# Altium schematic sheet 2.POWER.SchDoc
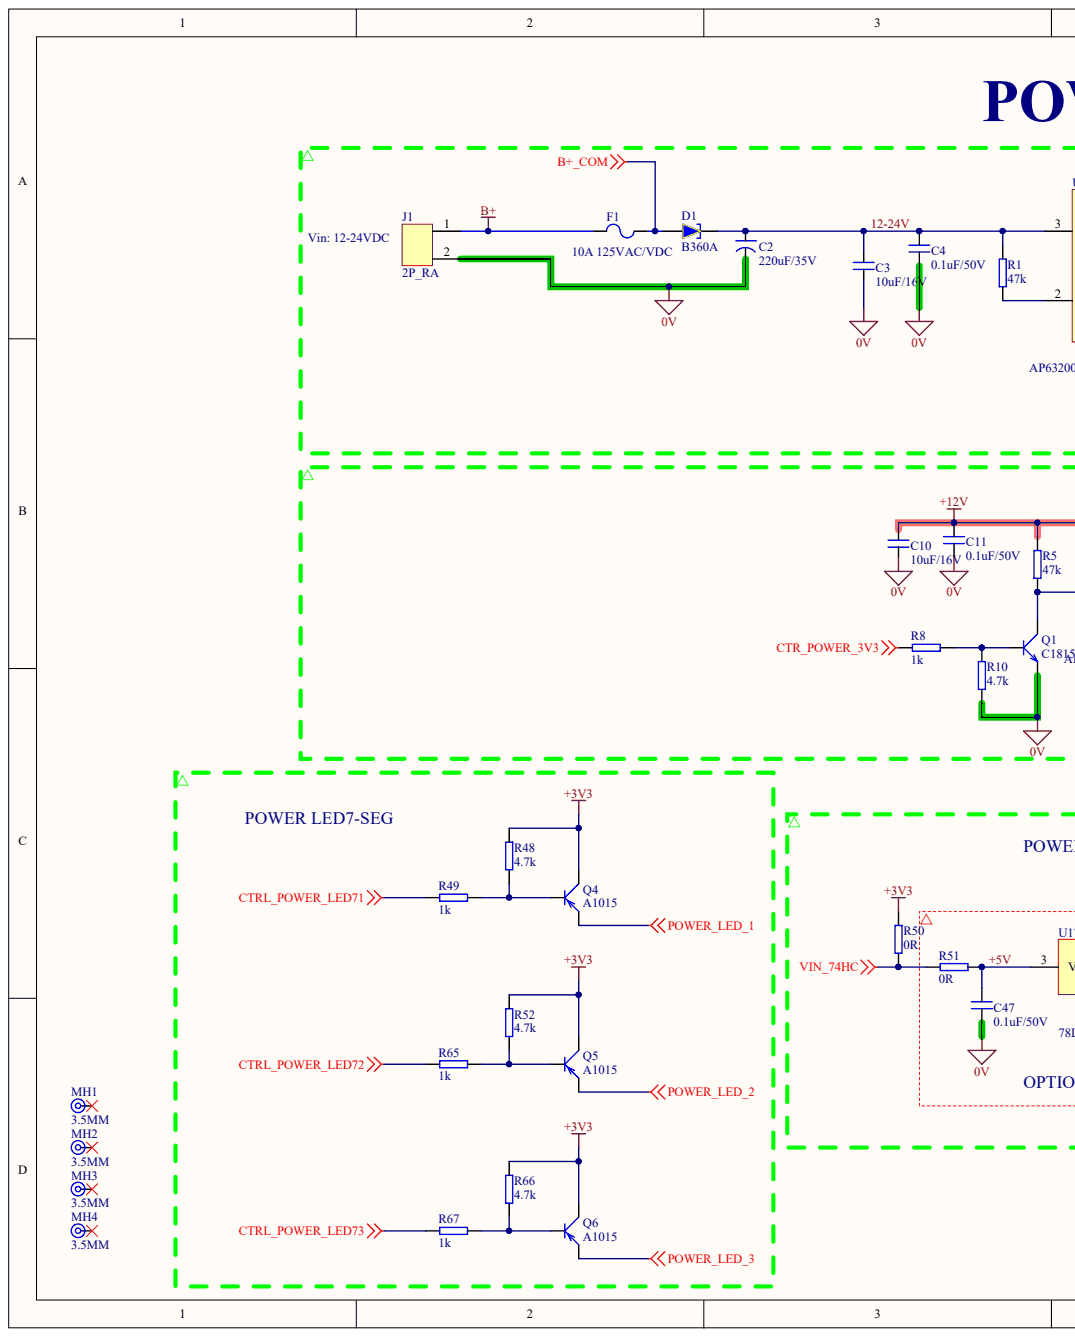
[diagram p0: 2.POWER.SchDoc, left]
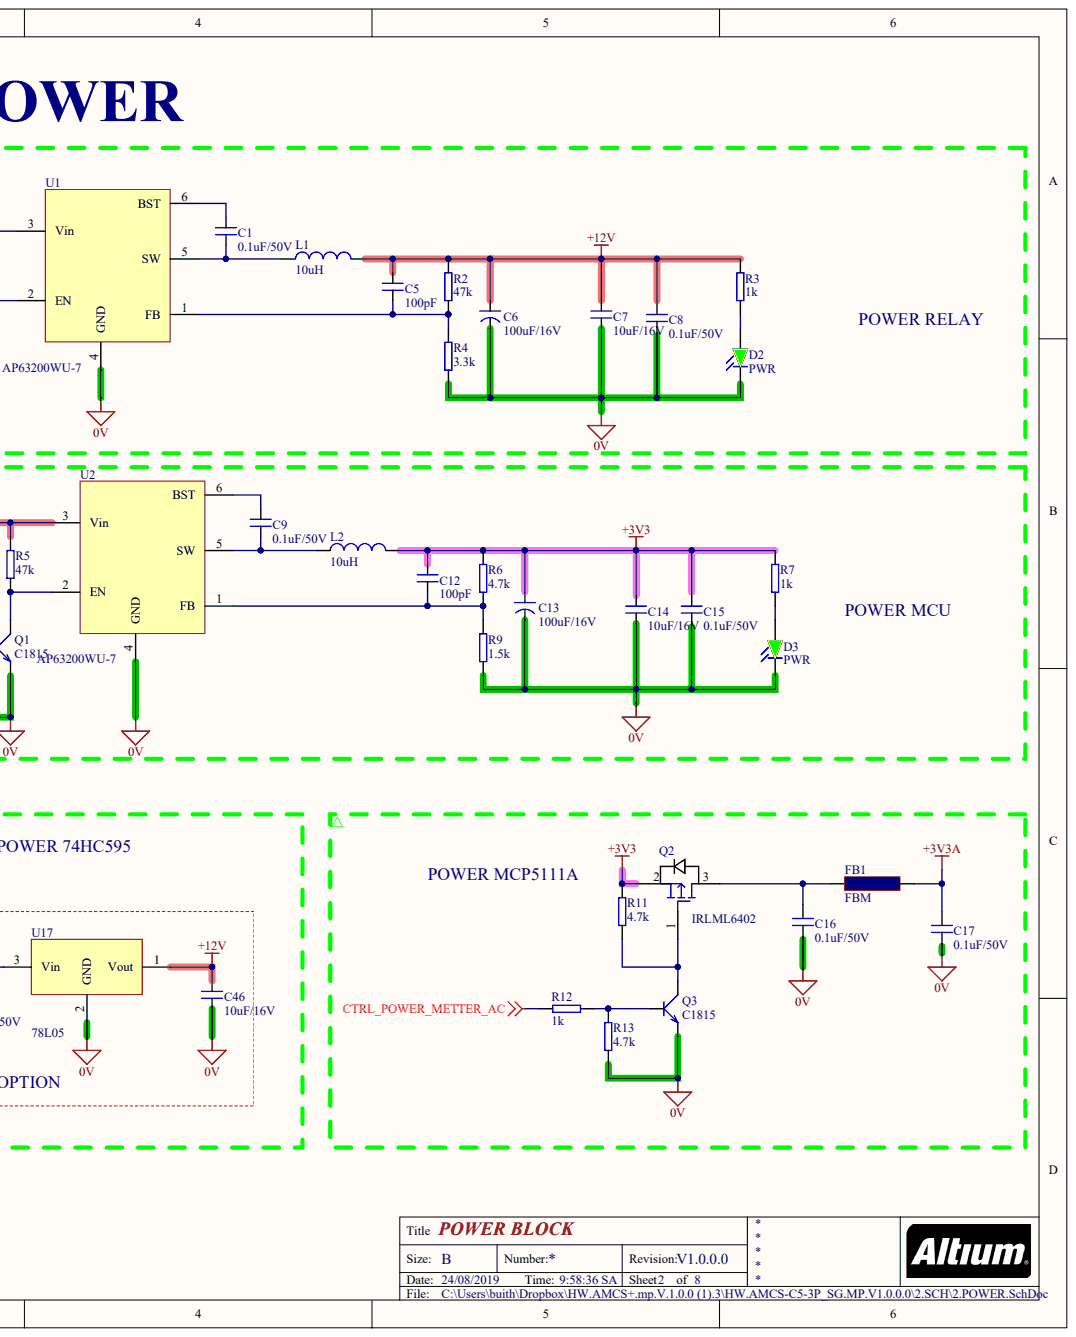
[diagram p1: 2.POWER.SchDoc, right]

## Parts
C1  0.1uF/50V  [0805]
C2  220uF/35V  [CAP ALU CASE E SMD]
C3  10uF/16V  [0805]
C4  0.1uF/50V  [0805]
C5  100pF  [0805]
C6  100uF/16V  [CAP_SMD_6.6x6.6x7.8mm]
C7  10uF/16V  [0805]
C8  0.1uF/50V  [0805]
C9  0.1uF/50V  [0805]
C10  10uF/16V  [0805]
C11  0.1uF/50V  [0805]
C12  100pF  [0805]
C13  100uF/16V  [CAP_SMD_6.6x6.6x7.8mm]
C14  10uF/16V  [0805]
C15  0.1uF/50V  [0805]
C16  0.1uF/50V  [0805]
C17  0.1uF/50V  [0805]
C46  10uF/16V  [0805]
C47  0.1uF/50V  [0805]
D1  B360A  [SMA]
D2  PWR  [0805_Pol]
D3  PWR  [0805_Pol]
F1  10A 125VAC/VDC  [451/453/449/452/454 Series]
FB1  FBM  [0805]
J1  2P_RA  [CONN_2x1_5.08_RA]
L1  10uH  [IND_8.3x8.3mm]
L2  10uH  [IND_8.3x8.3mm]
MH1  3.5MM  [TP_3.5]
MH2  3.5MM  [TP_3.5]
MH3  3.5MM  [TP_3.5]
MH4  3.5MM  [TP_3.5]
Q1  C1815  [SOT-23]
Q2  IRLML6402  [SOT-23]
Q3  C1815  [SOT-23]
Q4  A1015  [SOT-23]
Q5  A1015  [SOT-23]
Q6  A1015  [SOT-23]
R1  47k  [0805]
R2  47k  [0805]
R3  1k  [0805]
R4  3.3k  [0805]
R5  47k  [0805]
R6  4.7k  [0805]
R7  1k  [0805]
R8  1k  [0805]
R9  1.5k  [0805]
R10  4.7k  [0805]
R11  4.7k  [0805]
R12  1k  [0805]
R13  4.7k  [0805]
R48  4.7k  [0805]
R49  1k  [0805]
R50  0R  [0805]
R51  0R  [0805]
R52  4.7k  [0805]
R65  1k  [0805]
R66  4.7k  [0805]
R67  1k  [0805]
U1  AP63200WU-7  [TSOT26]
U2  AP63200WU-7  [TSOT26]
U17  78L05  [SOT-89]

## Nets
0V: C2.2 C3.2 C4.2 C6.2 C7.2 C8.2 C10.2 C11.2 C13.2 C14.2 C15.2 C16.2 C17.2 C46.2 C47.2 D2.2 D3.2 J1.2 Q1.2 Q3.2 R4.1 R9.1 R10.1 R13.1 U1.4(GND) U2.4(GND) U17.2
+3V3: C12.2 C13.1 C14.1 C15.1 L2.2 Q2.2 Q4.3 Q5.3 Q6.3 R6.2 R7.1 R11.2 R48.1 R50.1 R52.1 R66.1
+3V3A: C17.1 FB1.2
+12V: C5.2 C6.1 C7.1 C8.1 C10.1 C11.1 C46.1 L1.2 R2.2 R3.1 R5.2 U2.3(Vin) U17.1
B+: F1.1 J1.1
B+_COM: D1.1 F1.2
CTR_POWER_3V3: R8.2
CTRL_POWER_LED71: R49.2
CTRL_POWER_LED72: R65.2
CTRL_POWER_LED73: R67.2
CTRL_POWER_METTER_AC: R12.2
POWER_LED_1: Q4.2
POWER_LED_2: Q5.2
POWER_LED_3: Q6.2
VIN_74HC: R50.2 R51.2
12-24V: C2.1 C3.1 C4.1 D1.2 R1.2 U1.3(Vin)
+5V: C47.1 R51.1 U17.3
NetC1_1: C1.1 L1.1 U1.5(SW)
NetC1_2: C1.2 U1.6(BST)
NetC5_1: C5.1 R2.1 R4.2 U1.1(FB)
NetC9_1: C9.1 L2.1 U2.5(SW)
NetC9_2: C9.2 U2.6(BST)
NetC12_1: C12.1 R6.1 R9.2 U2.1(FB)
NetC16_1: C16.1 FB1.1 Q2.3
NetD2_1: D2.1 R3.2
NetD3_1: D3.1 R7.2
NetQ1_1: Q1.1 R8.1 R10.2
NetQ1_3: Q1.3 R5.1 U2.2(EN)
NetQ2_1: Q2.1 Q3.3 R11.1
NetQ3_1: Q3.1 R12.1 R13.2
NetQ4_1: Q4.1 R48.2 R49.1
NetQ5_1: Q5.1 R52.2 R65.1
NetQ6_1: Q6.1 R66.2 R67.1
NetR1_1: R1.1 U1.2(EN)

Not connected: MH1 1; MH2 1; MH3 1; MH4 1

## Library data of the parts
C1 C9 = Cap_0.1uF_0805: CAP CER 0.1UF 50V Y5V 0805; MFR: Yageo; Part Number: CC0805ZRY5V9BB104; AMCS CODE: VT-CAP-0004; ASS: SMT; Component Kind: Standard; Package: 0805; TYPE: CAP; Library Name: Schlib_Diepvn_V01.SchLib
C2 = Cap_Pol_220uF_35V: CAP ALUM 220UF 20% 35V SMD; MFR: Nichicon; Part Number: UWT1V221MNL1GS; ASS: SMT; Component Kind: Standard; Package: 0.327" L x 0.327" W (8.30mm x 8.30mm); TYPE: CAP; Library Name: Schlib_Diepvn_V01.SchLib
C3 C7 C10 C14 C46 = Cap_10uF_0805: CAP CER 10UF 16V 20% X5R 0805; MFR: Murata; Part Number: GRM21BR61E106KA73L; AMCS CODE: VT-CAP-0005; ASS: SMT; Component Kind: Standard; Package: 0805; TYPE: CAP; Library Name: Schlib_Diepvn_V01.SchLib
C4 C8 C11 C15-C17 C47 = Cap_0.1uF: CAP CER 0.1UF 50V Y5V 0805; MFR: Yageo; Part Number: CC0805ZRY5V9BB104; AMCS CODE: VT-CAP-0004; ASS: SMT; Component Kind: Standard; Package: 0805; Library Name: Schlib_Diepvn_V01.SchLib
C5 C12 = CAP_100pF_0805: CAP CER 100PF 50V X7R 0805; MFR: Yageo; Part Number: CC0805KRX7R9BB101; Vender Part: 311-3603-2-ND; ASS: SMT; LINK 1: *https://kme.com.vn/products/tu-gom-0805-100pf-x7r-50v; Vender: Digikey; Component Kind: Standard; Package: 0805 (2012 Metric); TYPE: CAP; Library Name: Schlib_Diepvn_V01.SchLib
C6 C13 = Cap_Pol_220uF: CAP ALUM 100UF 20% 16V SMD; MFR: Nichicon; Part Number: UWX1C101MCL1GB; AMCS CODE: VT-CAP-0002; ASS: SMT; Component Kind: Standard; Package: 6.6x6.6mm; TYPE: CAP; Library Name: Schlib_Diepvn_V01.SchLib
D1 = DIODE_SHTK_3A_60V_SMA: DIODE SCHOTTKY 60V 3A SMA; MFR: ON Semiconductor; Part Number: B360A-13-F; Component Kind: Standard; Package: SMA; Library Name: Schlib_Diepvn_V01.SchLib
D2 D3 = LED_Gr: LED RED CLEAR 0805 SMD; MFR: Lite-On; Part Number: LTST-C171KRKT; AMCS CODE: VT-DIO-0006; Component Kind: Standard; Package: 0805; Library Name: Schlib_Diepvn_V01.SchLib
F1 = Fuses_10A 125VAC: FUSE BRD MNT 5A 125VAC/VDC 2SMD; MFR: Littelfuse Inc.; Part Number: 0453010.MR; Vender Part: F3141CT-ND; Vender: Digikey; Component Kind: Standard; Package: 2-SMD, Square End Block; Size / Dimension: 0.240" L x 0.106" W x 0.106" H (6.10mm x 2.69mm x 2.69mm); Library Name: Schlib_Diepvn_V01.SchLib
FB1 = FERRITBEAD_400mA_0805: FERRITE BEAD 60 OHM 0805 1LN; MFR: Taiyo Yuden; Part Number: BKP2125HS600-T; AMCS CODE: VT-IND-0001; ASS: SMT; TYPE: IND; Component Kind: Standard; Package: 0805; Library Name: Schlib_Diepvn_V01.SchLib
J1 = CON_2_5.08: HEADER 2 AC 5.08MM EDGE 90 DEG; Part Number: CON2 2EDG5.08-2P; AMCS CODE: VT-CON-0013; ASS: TH; Component Kind: Standard; Package: Pitch: 5.08MM; TYPE: CONN; Library Name: Schlib_Diepvn_V01.SchLib
L1 L2 = IND_10uH_7.6x6.5: FIXED IND 10UH 3.5A 60 MOHM SMD; MFR: Bourns Inc.; Part Number: SRP7030-100FM; Vender Part: PM124SH-100M-RCCT-ND; ASS: SMT; Vender: Digikey; Component Kind: Standard; Package: 0.299" L x 0.256" W (7.60mm x 6.50mm); TYPE: IND; Library Name: Schlib_Diepvn_V01.SchLib
MH1-MH4 = Test Point Huge_3.5MM: Component Kind: Standard; Description: 3.5MM; Library Name: Schlib_Diepvn_V01.SchLib
Q1 Q3 = MMBT3904: TRANS NPN 40V 0.2A SOT-23; MFR: ON Semiconductor; Part Number: 2SC1815 HF; AMCS CODE: VT-DIO-0012; ASS: SMT; TYPE: TRAN; Component Kind: Standard; Package: SOT-23; Part Number 1: MMBT3904; Library Name: Schlib_Diepvn_V01.SchLib
Q2 = IRLML6402: MOSFET P-CH 20V 3.7A SOT-23; MFR: International Rectifier; Part Number: IRLML6402TRPBF; Vender Part: IRLML6402PBFCT-ND; Eposi Code: NVLC.TRA0001; Vender: Digikey; Component Kind: Standard; Package: SOT-23; Library Name: Schlib_Diepvn_V01.SchLib
Q4-Q6 = MMBT3906: TRANS PNP 40V 0.2A SOT23; MFR: ON Semiconductor; Part Number: 2SC1815 HF; Part Number 1: MMBT3904; ASS: SMT; TYPE: TRAN; Component Kind: Standard; LINK: http://linhkienchatluong.vn/transistor/a1015-ba-pnp-transistor-015a-60v-sot-23_sp787_ct325.aspx; Package: SOT-23; Library Name: Schlib_Diepvn_V01.SchLib
R1 R2 R5 = Res_47k_0805: RES SMD 47K OHM 1% 1/8W 0805; MFR: Panasonic Electronic Components; Part Number: ERJ-6ENF4702V; AMCS CODE: VT-RES-0006; ASS: SMT; Component Kind: Standard; Package: 0805; TYPE: RES; Library Name: Schlib_Diepvn_V01.SchLib
R3 R7 = Res_1k_0805: RES SMD 1K OHM 1% 1/8W 0805; MFR: Yageo; Part Number: RC0805FR-071KL; Vender PartNumber: 311-1.00KCRTR-ND; AMCS CODE: VT-RES-0003; ASS: SMT; Detailed Description: 1 kOhms ±1% 0.125W, 1/8W Chip Resistor 0805 (2012 Metric) Moisture Resistant Thick Film; Power (Watts): 0.125W, 1/8W; Resistance: 1 kOhms; Temperature Coefficient: ±100ppm/°C; Vender: Digi-Key; Component Kind: Standard; Operating Temperature: -55°C ~ 155°C; Package: 0805 (2012 Metric); Size / Dimension: 0.079" L x 0.049" W (2.00mm x 1.25mm); Tolerance: ±1%; TYPE: RES; Library Name: Schlib_Diepvn_V01.SchLib
R4 = Res_3.3k_0805: RES SMD 3.3K OHM 1% 1/8W 0805; MFR: Yageo; Part Number: RC0805FR-073K3L; Vender Part: 311-3.30KCRTR-ND; AMCS CODE: VT-RES-0016; ASS: SMT; Vender: Digikey; Component Kind: Standard; Package: 0805; TYPE: RES; Library Name: Schlib_Diepvn_V01.SchLib
R6 R10 R11 R13 R48 R52 R66 = Res_4.7k_0805: RES SMD 4.7K OHM 1% 1/8W 0805; MFR: Yageo; Part Number: RC0805FR-074K7L; AMCS CODE: VT-RES-0004; ASS: SMT; Component Kind: Standard; Package: 0805; TYPE: RES; Library Name: Schlib_Diepvn_V01.SchLib
R8 R12 R49 R65 R67 = Res_1k_0805: RES 1K OHM 1/10W 1% 0603 SMD; MFR: Yageo; Part Number: RC0805FR-071KL; AMCS CODE: VT-RES-0003; ASS: SMT; Component Kind: Standard; Package: 0805; TYPE: RES; Library Name: Schlib_Diepvn_V01.SchLib
R9 = Res_1.5k_0805: RES SMD 1.5K OHM 1% 1/8W 0805; MFR: Yageo; Part Number: RC0805FR-071K5L; AMCS CODE: VT-RES-0014; ASS: SMT; Component Kind: Standard; Package: 0805; TYPE: RES; Library Name: Schlib_Diepvn_V01.SchLib
R50 R51 = Res_0R_0805: RES SMD 0.0 OHM JUMPER 1/8W 0805; MFR: Yageo; Part Number: RC0805JR-070RL; AMCS CODE: VT-RES-0011; ASS: SMT; Component Kind: Standard; Package: 0805; TYPE: RES; Library Name: Schlib_Diepvn_V01.SchLib
U1 U2 = AP63200WU-7: IC REG BUCK ADJUSTABLE 2A TSOT26; MFR: Diodes Incorporated; Vender Part Number: AP63200WU-7DITR-ND; ASS: SMT; Frequency - Switching: 500kHz; Function: Step-Down; Vender: Digi-Key; Voltage - Input: 3.8V - 32V; Voltage - Output (Max): 12V; Component Kind: Standard; Current - Output: 2A; Operating Temperature: -40°C ~ 85°C (TA); Package: SOT-23-6 Thin, TSOT-23-6; Part Number: AP63200WU-7; TYPE: IC; Library Name: Schlib_Diepvn_V01.SchLib
U17 = 7805: IC REG LINEAR 5V 100MA SOT89-3; MFR: STMicroelectronics; Part Number: L78L05ACUTR; Vender Part: 497-1183-6-ND; ASS: SMT; PSRR: 49dB (120Hz); Vender: Digikey; Voltage - Input (Max): 30V; Component Kind: Standard; LINK: http://linhkienchatluong.vn/ic-on-ap-78xx-79xx/78l05-7805-01a-5v-sot-89-_sp1000_ct303.aspx; Operating Temperature: 0°C ~ 125°C; Package: SOT-89-3; TYPE: IC; Library Name: Schlib_Diepvn_V01.SchLib
